# Altium schematic sheet USB_PWR.SchDoc
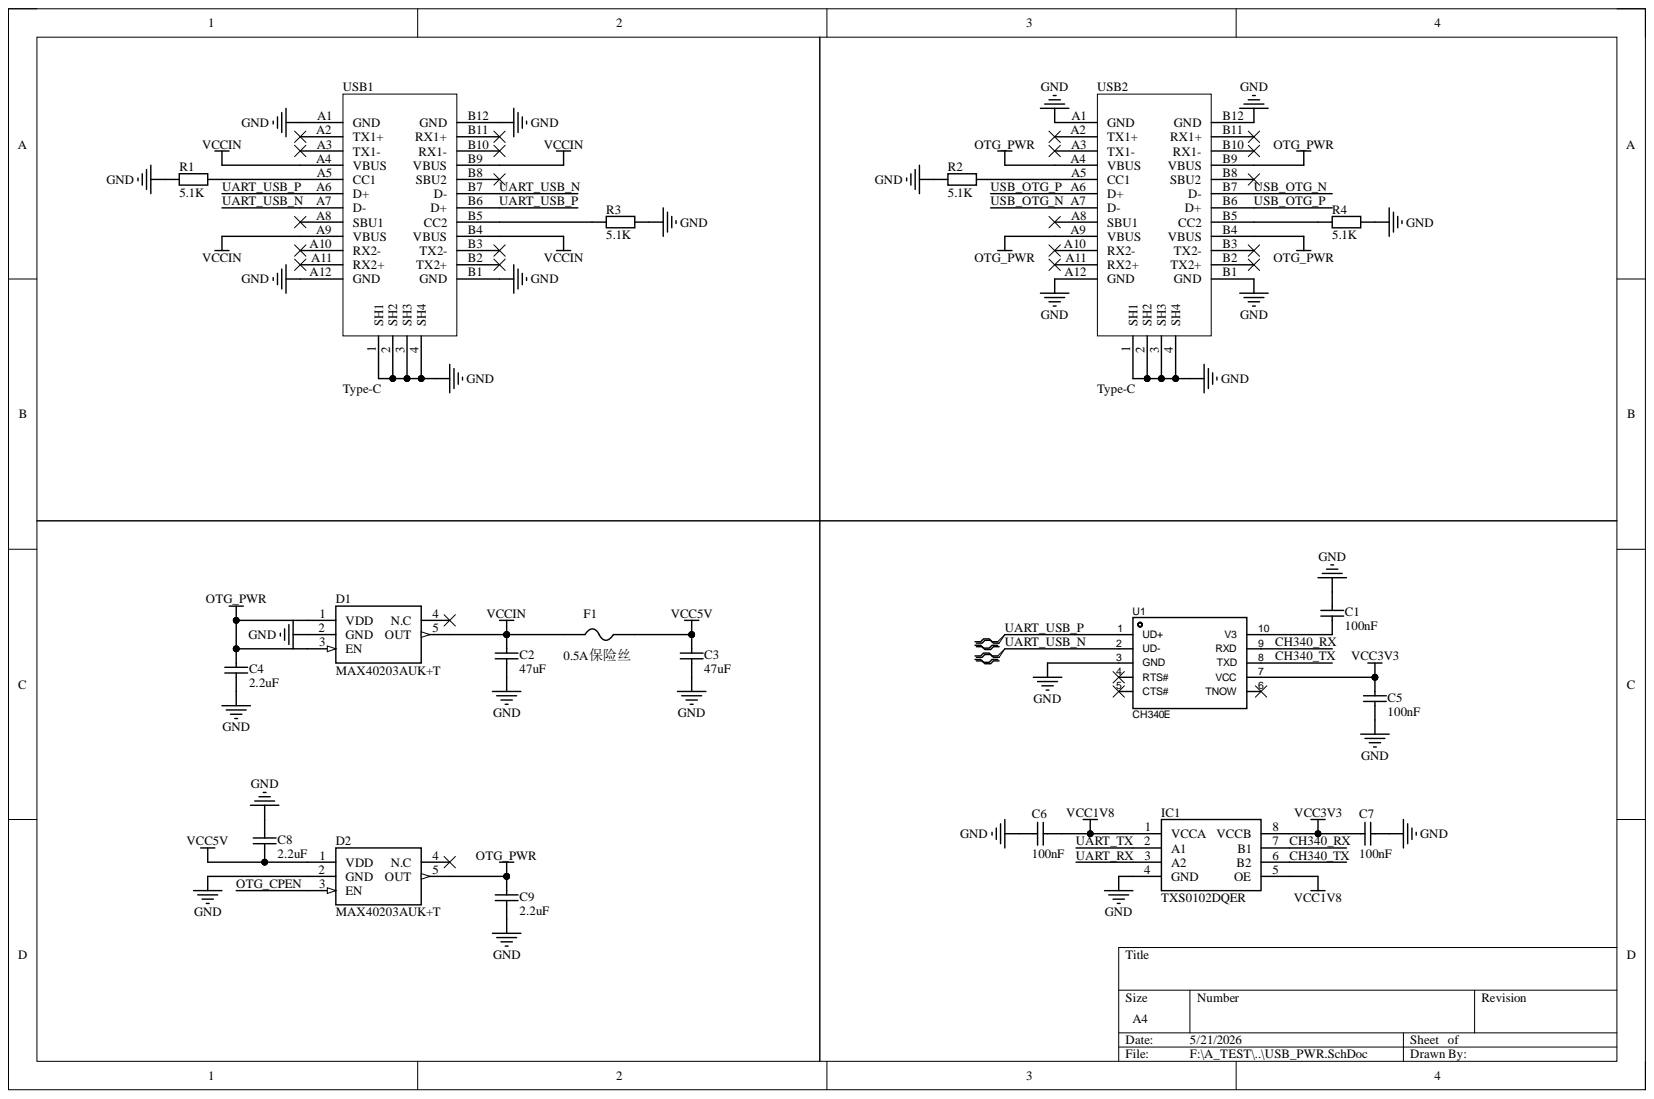
[diagram p0: USB_PWR.SchDoc]

## Parts
C1  100nF  [C 0402_L]
C2  47uF  [C 0805_L]
C3  47uF  [C 0805_L]
C4  2.2uF  [C 0603_L]
C5  100nF  [C 0402_L]
C6  100nF  [C 0402_L]
C7  100nF  [C 0402_L]
C8  2.2uF  [C 0603_L]
C9  2.2uF  [C 0603_L]
D1  MAX40203AUK+T  [SOT95P280X145-5N]
D2  MAX40203AUK+T  [SOT95P280X145-5N]
F1  0.5A保险丝  [FU 0805]
IC1  TXS0102DQER  [SON35P100X140X40-8N]
R1  5.1K  [R 0402_L]
R2  5.1K  [R 0402_L]
R3  5.1K  [R 0402_L]
R4  5.1K  [R 0402_L]
U1  CH340E  [MSOP-10_L3.0-W3.0-P0.50-LS5.0-BL]
USB1  Type-C  [TYPE-C-16P]
USB2  Type-C  [TYPE-C-16P]

## Nets
GND: C1.1 C2.1 C3.1 C4.1 C5.2 C6.1 C7.2 C8.1 C9.1 D1.2(GND) D2.2(GND) IC1.4(GND) R1.1 R2.1 R3.1 R4.2 U1.3(GND) USB1.1(SH1) USB1.2(SH2) USB1.3(SH3) USB1.4(SH4) USB1.A1(GND) USB1.A12(GND) USB1.B1(GND) USB1.B12(GND) USB2.1(SH1) USB2.2(SH2) USB2.3(SH3) USB2.4(SH4) USB2.A1(GND) USB2.A12(GND) USB2.B1(GND) USB2.B12(GND)
OTG_PWR: C4.2 C9.2 D1.1(VDD) D1.3(EN) D2.5(OUT) USB2.A4(VBUS) USB2.A9(VBUS) USB2.B4(VBUS) USB2.B9(VBUS)
VCC1V8: C6.2 IC1.1(VCCA) IC1.5(OE)
VCC3V3: C5.1 C7.1 IC1.8(VCCB) U1.7(VCC)
VCC5V: C3.2 C8.2 D2.1(VDD) F1.2
VCCIN: C2.2 D1.5(OUT) F1.1 USB1.A4(VBUS) USB1.A9(VBUS) USB1.B4(VBUS) USB1.B9(VBUS)
CH340_RX: IC1.7(B1) U1.9(RXD)
CH340_TX: IC1.6(B2) U1.8(TXD)
OTG_CPEN: D2.3(EN)
UART_RX: IC1.3(A2)
UART_TX: IC1.2(A1)
UART_USB_N: U1.2(UD-) USB1.A7(D-) USB1.B7(D-)
UART_USB_P: U1.1(UD+) USB1.A6(D+) USB1.B6(D+)
USB_OTG_N: USB2.A7(D-) USB2.B7(D-)
USB_OTG_P: USB2.A6(D+) USB2.B6(D+)
NetC1_2: C1.2 U1.10(V3)
NetR1_2: R1.2 USB1.A5(CC1)
NetR2_2: R2.2 USB2.A5(CC1)
NetR3_2: R3.2 USB1.B5(CC2)
NetR4_1: R4.1 USB2.B5(CC2)

Not connected: D1 4(N.C); D2 4(N.C); U1 4(RTS#) 5(CTS#) 6(TNOW); USB1 A2(TX1+) A3(TX1-) A8(SBU1) A10(RX2-) A11(RX2+) B2(TX2+) B3(TX2-) B8(SBU2) B10(RX1-) B11(RX1+); USB2 A2(TX1+) A3(TX1-) A8(SBU1) A10(RX2-) A11(RX2+) B2(TX2+) B3(TX2-) B8(SBU2) B10(RX1-) B11(RX1+)

## Library data of the parts
C1-C9 = Cap: 贴片电容; Component Kind: Standard; Library Name: A_Schlib.SchLib
  C1 C5-C7: value: 100nF
  C2 C3: value: 47uF
  C4 C8 C9: value: 2.2uF
D1 D2 = MAX40203AUK+T: Diode; Manufacturer_Name: Analog Devices; Arrow Part Number: MAX40203AUK+T; Arrow Price/Stock: https://www.arrow.com/en/products/max40203aukt/analog-devices?region=nac; Component Kind: Standard; Datasheet Link: http://www.mouser.com/datasheet/2/256/MAX40203-1517812.pdf; Height: 1.45mm; Library Name: SamacSys.SchLib; Manufacturer_Part_Number: MAX40203AUK+T; Mouser Part Number: 700-MAX40203AUK+T; Mouser Price/Stock: https://www.mouser.co.uk/ProductDetail/Analog-Devices-Maxim-Integrated/MAX40203AUK%2bT?qs=l7cgNqFNU1j1dlDj29Iz0A%3D%3D
F1 = FU: 贴片保险丝; 版权归属: 封装库来自淘宝卖家 ID：黄晶宝石; BOM名称: 贴片保险丝; Component Kind: Standard; Library Name: A_Schlib.SchLib; 学习资源: https://shop463601444.taobao.com
IC1 = TXS0102DQER: Integrated Circuit; Manufacturer_Name: Texas Instruments; Arrow Part Number: TXS0102DQER; Arrow Price/Stock: https://www.arrow.com/en/products/txs0102dqer/texas-instruments?utm_currency=USD&region=nac; Component Kind: Standard; Datasheet Link: http://www.ti.com/lit/gpn/txs0102; Height: 0.4mm; Library Name: SamacSys.SchLib; Manufacturer_Part_Number: TXS0102DQER; Mouser Part Number: 595-TXS0102DQER; Mouser Price/Stock: https://www.mouser.co.uk/ProductDetail/Texas-Instruments/TXS0102DQER?qs=THnoWt2ah2Cp3NeGaO%252BnBA%3D%3D
R1 R3 = Res: 通用; Component Kind: Standard; Library Name: A_Schlib.SchLib; value: 5.1K
R2 R4 = Res: Component Kind: Standard; value: 5.1K; Library Name: 零散元件库.SCHLIB
U1 = CH340E: 应用功能:收发器;USB协议版本:USB 2.0;数据速率:2Mbps;; Manufacturer: WCH(南京沁恒); AddInBom: yes; Applications Function: 收发器; Current - Supply: 20mA; Data Rate: 2Mbps; ModelId: b42a31f9e1fa4643bc7ea573b9bba7ed聨0819f05c4eef4c71ace90d822a990e87; ModelName: MSOP-10_L3.0-W3.0-H1.1-LS5.0-P0.50; ModelTransform: 118.11,196.85,0,0,0,0,0,0,0; Supply Current (Iq): 150uA; USB Protocol: USB 2.0; Voltage - Supply: 3.3V；5V; Component Kind: Standard; ConvertToPcb: yes; Datasheet: https://item.szlcsc.com/datasheet/CH340E/100866.html; Device: CH340E; FootprintName: MSOP-10_L3.0-W3.0-P0.50-LS5.0-BL; JLCPCB Part Class: Extended Part; LCSC Part Name: USB转串口芯片CH340; Library Name: SamacSys.SchLib; ManufacturerPart: CH340E; Supplier: LCSC; Supplier Footprint: MSOP-10; SupplierPart: C99652; Symbol: CH340E
USB1 = Type-C-16P: 带通信; Component Kind: Standard; Library Name: A_Schlib.SchLib
USB2 = Type-C: Component Kind: Standard; Library Name: 零散元件库.SCHLIB
